# Altium schematic sheet 1power.SchDoc(1power)
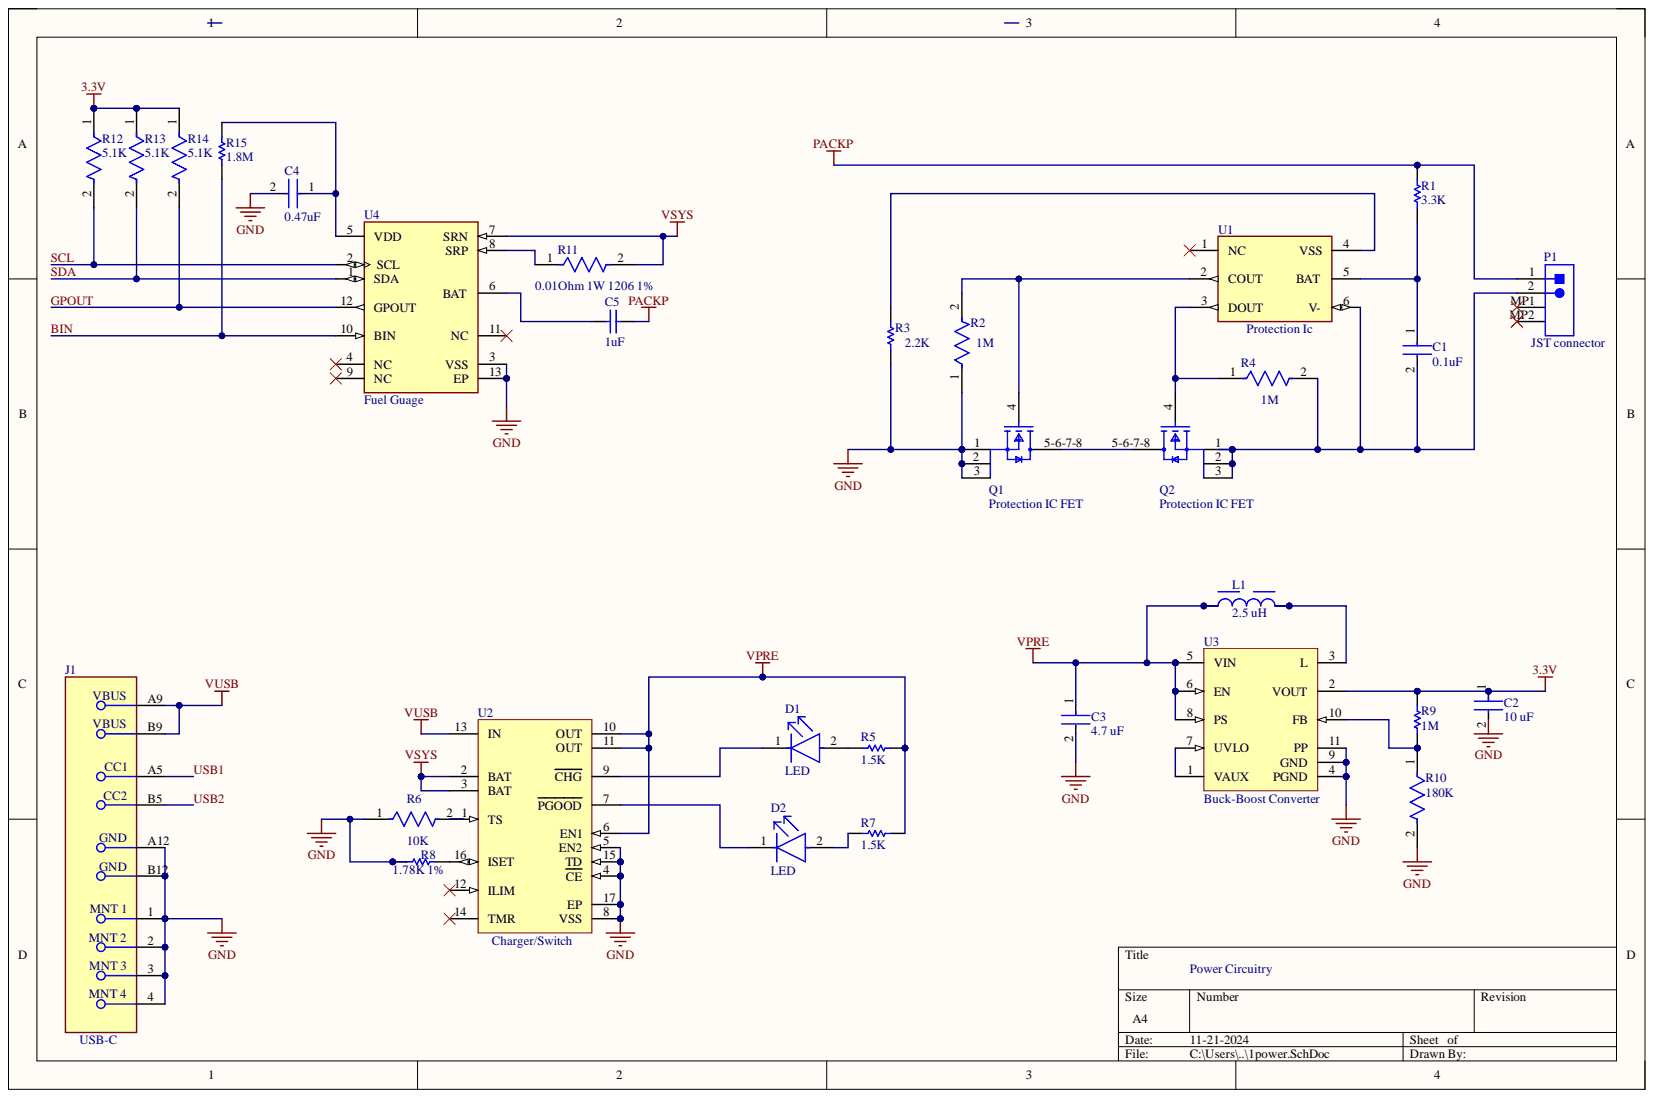
[diagram p0: 1power.SchDoc]

## Parts
C1  0.1uF  [FP-GRM188-0_1-e0_2_0_5-IPC_B]
C2  10 uF  [FP-GRM188-0_1-e0_2_0_55-IPC_A]
C3  4.7 uF  [FP-GRM188-0_15-MFG]
C4  0.47uF  [FP-GRM188-0_1-e0_2_0_5-IPC_B]
C5  1uF  [CAPC1608X87X35LL15T15]
D1  LED  [FP-ASMD-CWG7-NX7D2-MFG]
D2  LED  [FP-ASMD-CWG7-NX7D2-MFG]
J1  USB-C  [FP-USB4125-GF-A-0190-MFG]
L1  2.5 uH  [WE-TPC_3816]
P1  JST connector  [JST- S2B-PH-SM4-TB(LF)(SN)-2_V]
Q1  Protection IC FET  [FP-DQG0008A-MFG]
Q2  Protection IC FET  [FP-DQG0008A-MFG]
R1  3.3K  [RESC1608X55X30LL15T15]
R2  1M  [FP-RC0603-0_55-MFG]
R3  2.2K  [RESC1608X55X25ML10T15]
R4  1M  [FP-RC0603-0_55-MFG]
R5  1.5K  [RESC1609X50X30ML10T20]
R6  10K  [FP-RC0603-0_55-IPC_C]
R7  1.5K  [RESC1609X50X30ML10T20]
R8  1.78K 1%  [RESC1608X55X30LL15T15]
R9  1M  [RESC1608X55X25LL10T15]
R10  180K  [FP-CRCW0603-e3-IPC_A]
R11  0.01Ohm 1W 1206 1%  Resistance Tolerance: 1%  [FP-WSLP1206-FP_1_65x1_93x-MFG]
R12  5.1K  [FP-CRCW0603-e3-IPC_C]
R13  5.1K  [FP-CRCW0603-e3-IPC_C]
R14  5.1K  [FP-CRCW0603-e3-IPC_C]
R15  1.8M  [RESC1608X55X30ML15T15]
U1  Protection Ic  [FP-DSE0006A-IPC_A]
U2  Charger/Switch  [RGT0016C_V]
U3  Buck-Boost Converter  [DRC0010J_V]
U4  Fuel Guage  [FP-DRZ0012A-MFG]

## Nets
3.3V: C2.1 R9.1 R12.1 R13.1 R14.1 U3.2(VOUT)
GND: C2.2 C3.2 C4.2 J1.1 J1.2 J1.3 J1.4 J1.A12 J1.B12 Q1.1 Q1.2 Q1.3 R2.1 R3.2 R6.1 R8.2 R10.2 U2.4(CE) U2.5(EN2) U2.8(VSS) U2.15(TD) U2.17(EP) U3.4(PGND) U3.9(GND) U3.11(PP) U4.3(VSS) U4.13(EP)
PACKP: C5.2 P1.1 R1.1
VPRE: C3.1 L1.1 R5.2 R7.2 U2.6(EN1) U2.10(OUT) U2.11(OUT) U3.5(VIN) U3.6(EN) U3.8(PS)
VSYS: R11.2 U2.2(BAT) U2.3(BAT) U4.7(SRN)
VUSB: J1.A9 J1.B9 U2.13(IN)
BIN: R15.2 U4.10(BIN)
GPOUT: R14.2 U4.12(GPOUT)
SCL: R12.2 U4.2(SCL)
SDA: R13.2 U4.1(SDA)
USB1: J1.A5
USB2: J1.B5
NetC1_1: C1.1 R1.2 U1.5(BAT)
NetC1_2: C1.2 P1.2 Q2.1 Q2.2 Q2.3 R4.2 U1.6(V-)
NetC4_1: C4.1 R15.1 U4.5(VDD)
NetC5_1: C5.1 U4.6(BAT)
NetD1_1: D1.1 U2.9(CHG)
NetD1_2: D1.2 R5.1
NetD2_1: D2.1 U2.7(PGOOD)
NetD2_2: D2.2 R7.1
NetL1_2: L1.2 U3.3(L)
NetQ1_4: Q1.4 R2.2 U1.2(COUT)
NetQ1_5-6-7-8: Q1.5-6-7-8 Q2.5-6-7-8
NetQ2_4: Q2.4 R4.1 U1.3(DOUT)
NetR3_1: R3.1 U1.4(VSS)
NetR6_2: R6.2 U2.1(TS)
NetR8_1: R8.1 U2.16(ISET)
NetR9_2: R9.2 R10.1 U3.10(FB)
NetR11_1: R11.1 U4.8(SRP)
NetU3_1: U3.1(VAUX) U3.7(UVLO)

Not connected: P1 MP1 MP2; U1 1(NC); U2 12(ILIM) 14(TMR); U4 4(NC) 9(NC) 11(NC)

## Library data of the parts
C1 = CMP-1035-02595-8: Chip Multilayer Ceramic Capacitors for General Purpose, 0603, 0.10uF, X7R, 15%, 10%, 100V; Capacitance: 100nF; Case Code (Imperial): 0603; Case Code (Metric): 1608; Case/Package: 0603; Component Kind: Standard; Depth: 0.8mm; Dielectric: X7R; Height: 0.8mm; Lead Free: Lead Free; Length: 1.6mm; Library Name: Altium Content Vault; Material: Ceramic; Max Operating Temperature: 125°C; Min Operating Temperature: -55°C; Mount: Surface Mount; Package Quantity: 1; Packaging: Tape and Reel; Radiation Hardening: No; REACH SVHC: No SVHC; Termination: SMD/SMT; Thickness: 0.035inch; Tolerance: 10%; Voltage: 100V; Voltage Rating: 100V; Voltage Rating (DC): 100V; Weight: 0.000222oz; Width: 0.8mm
C2 = CMP-2006-02792-2: Chip Multilayer Ceramic Capacitors for General Purpose, 0603, 10uF, X5R, 15%, 20%, 6.3V; Capacitance: 10uF; Case Code (Imperial): 0603; Case Code (Metric): 1608; Case/Package: 0603; Component Kind: Standard; Depth: 0.8mm; Dielectric: X5R; Height: 0.8mm; Lead Free: Lead Free; Length: 1.6mm; Library Name: Altium Content Vault; Material: Ceramic; Max Operating Temperature: 85°C; Min Operating Temperature: -55°C; Mount: Surface Mount; Package Quantity: 4000; Packaging: Tape and Reel; Radiation Hardening: No; REACH SVHC: No SVHC; Termination: SMD/SMT; Thickness: 0.035inch; Tolerance: 20%; Voltage: 6.3V; Voltage Rating: 6.3V; Voltage Rating (DC): 6.3V; Width: 0.031inch
C3 = CMP-06035-062935-2: CAP CER 4.7UF 16V X5R 0603; NexarPartId: 20311162; Capacitance: 4.7µF; Material: Ceramic; Tolerance: 10%; Case Code (Imperial): 0603; Case Code (Metric): 1608; Case/Package: 0603; Component Kind: Standard; Depth: 800µm; Dielectric: X5R; Height: 800µm; Lead Free: Lead Free; Length: 1.6mm; Library Name: Altium Content Vault; Lifecycle Status: Production; Max Operating Temperature: 85°C; Min Operating Temperature: -55°C; Mount: Surface Mount; Packaging: Tape & Reel (TR); REACH SVHC: No SVHC; RoHS: Compliant; Schedule B: 8532240020; Termination: SMD/SMT; Thickness: 939.8µm; Voltage Rating: 16V; Voltage Rating (DC): 16V; Weight: 6.293594mg; Width: 800µm
C4 = CMP-2006-02860-2: Chip Multilayer Ceramic Capacitors for General Purpose, 0603, 0.47uF, X7R, 15%, 10%, 16V; Capacitance: 470nF; Case Code (Imperial): 0603; Case Code (Metric): 1608; Case/Package: 0603; Component Kind: Standard; Depth: 0.8mm; Dielectric: X7R; Height: 0.8mm; Lead Free: Lead Free; Length: 1.6mm; Library Name: Altium Content Vault; Material: Ceramic; Max Operating Temperature: 125°C; Min Operating Temperature: -55°C; Mount: Surface Mount; Number of Pins: 2; Package Quantity: 1; Packaging: Tape and Reel; REACH SVHC: No SVHC; Termination: SMD/SMT; Thickness: 0.035inch; Tolerance: 10%; Voltage: 16V; Voltage Rating: 16V; Voltage Rating (DC): 16V; Width: 0.8mm
C5 = CMP-2006-03713-1: Manufacturer: Kemet; Part Number: C0603C105K3RACTU; Component Kind: Standard; Library Name: Altium Content Vault
D1 D2 = CMP-02129-107448438-1: ASMD-CWG7-NX7D2 ASMD-CWG7 Rectangle with Flat Top White Cool Surface Mount LED Indication Discrete 2.8V 20mA 2800mcd 120°; Luminous Intensity: 2.8cd; Max Junction Temperature (Tj): 110°C; Power Dissipation: 102mW; Case/Package: 1206; Color: White; Component Kind: Standard; Forward Current: 20mA; Forward Voltage: 2.8V; Height: 900µm; Library Name: Altium Content Vault; Max Operating Temperature: 85°C; Min Operating Temperature: -40°C; NexarPartId: 107448438; Viewing Angle: 120°
J1 = CMP-245163-000004-1: CONN RCPT TYPE C 6P SMD RA; Component Kind: Standard; Library Name: Schlib1.SchLib; Supplier 1: Digikey; Supplier Part Number 1: 2073-USB4125-GF-A-0190CT-ND
L1 = CMP-0227-00365-3: NexarPartId: 22803208; Core Material: Ferrite; Current Rating: 1.45A; DC Resistance (DCR): 50mΩ; Inductance: 2.5µH; Inductance Tolerance: 30%; Saturation Current: 1.25A; Self Resonant Frequency: 120MHz; Shielding: Shielded; Test Frequency: 100kHz; Ambient Temperature Range High: 95°C; Ambient Temperature Range Low: -40°C; Case/Package: Nonstandard; Component Kind: Standard; Composition: Wirewound; DC Current: 1.45A; Depth: 4.1mm; Height: 1.65mm; Height - Seated (Max): 1.8034mm; Lead Pitch: 1.1mm; Length: 3.8mm; Library Name: Altium Content Vault; Max DC Current: 1.45A; Max Operating Temperature: 125°C; Military Standard: Not; Min Operating Temperature: -40°C; Mount: Surface Mount; Number of Pins: 2; Packaging: Cut Tape (CT); REACH SVHC: Unknown; RMS Current (Irms): 1.45A; RoHS: Compliant; Termination: SMD/SMT; Tolerance: 30%; Weight: 102.993818mg; Width: 3.8mm
P1 = CMP-1755-00001-1: Male Header, Pitch 2 mm, 1 x 2 Position, Height 5.5 mm, -25 to 85 degC, RoHS, Tape and Reel; Current Rating: 3 A; Colour: Black; Component Kind: Standard; ComponentLink1Description: Manufacturer URL; ComponentLink1URL: http://www.jst.com/home2.html; ComponentLink2Description: Datasheet; ComponentLink2URL: http://www.jst-mfg.com/product/pdf/eng/ePH.pdf; Contact Finish: Lead; Contact Material: Bronze; Contact Plating: Tin; DatasheetVersion: Dec-12; Height: 8.13 mm; Lead Pitch: 0.1 inch; Length: 101.19 mm; Library Name: Altium Content Vault; Max Operating Temperature: 105degC; Min Operating Temperature: -65degC; Mount: Through Hole; Package Quantity: 100; PackageDescription: Male Header, Pitch 2 mm, 1 x 2 Position, Height 5.5 mm; PackageReference: S2B-PH-SM4-TB(LF)(SN)-2; Packaging: Bulk; Pins: 40; Radiation Hardening: No; RoHSCompliant: True; Width: 2.34 mm
Q1 Q2 = CMP-04962-000044-1: Protection IC FET, MOSFET N-CH 25V 60A 8-SON, CSD16406Q3; Element Configuration: Single; Fall Time: 4.8ns; Gate to Source Voltage (Vgs): 16V; Input Capacitance: 1.1nF; Max Power Dissipation: 2.7W; Nominal Vgs: 1.7V; Number of Elements: 1; Polarity: N-CHANNEL; Rds On Max: 5.3mR; Rise Time: 12.9ns; Turn-Off Delay Time: 8.5ns; Turn-On Delay Time: 7.3ns; Case/Package: SON; Component Kind: Standard; Contact Plating: Tin; Continuous Drain Current (ID): 60A; Drain to Source Breakdown Voltage: 25V; Drain to Source Voltage (Vdss): 25V; Dual Supply Voltage: 25V; Lead Free: Contains Lead; Library Name: Schlib1.SchLib; Max Operating Temperature: 150°C; Min Operating Temperature: -55°C; Mount: Surface Mount; Number of Pins: 8; Package Quantity: 1; Packaging: Tape and Reel; Power Dissipation: 2.7W; Radiation Hardening: No; REACH SVHC: No SVHC; RoHS Compliant: Yes; Series: NexFET™; Supplier 1: Mouser; Supplier Part Number 1: 595-CSD16406Q3; Termination: SMD/SMT; Threshold Voltage: 1.7V
R1 = CMP-2000-00435-2: Chip Resistor, 330 Ohm, +/- 1%, 0.1 W, -55 to 155 degC, 0603 (1608 Metric), RoHS, Tape and Reel; Current Rating: 500 mA; Gender: Male; Mating Cycles: 10000; Voltage Rating: 10 V; Component Kind: Standard; ComponentLink1Description: Manufacturer URL; ComponentLink1URL: http://www.panasonic.com/; ComponentLink2Description: Datasheet; ComponentLink2URL: http://industrial.panasonic.com/cdbs/www-data/pdf/RDA0000/AOA0000C86.pdf; Contact Finish: Gold; Contact Material: Copper; Contact Plating: Gold; DatasheetVersion: Apr-15; Height: 1.45 mm; Lead Pitch: 1.1 mm; Length: 13.7 mm; Library Name: Altium Content Vault; Max Operating Temperature: 85 degC; Min Operating Temperature: -25 degC; Mount: Surface Mount; Package Quantity: 1500; PackageDescription: 2-Pin Surface Mount Device, Body 1.6 x 0.85 mm; PackageReference: 0603; Packaging: Tape and Reel; Pins: 8; REACH SVHC: No SVHC; RoHSCompliant: true; Width: 1.45 mm
R2 R4 = CMP-2000-06953-2: 1MOhm, RC0603JR-071ML, RES SMD 1M OHM 5% 1/10W 0603; Max Power Dissipation: 100mW; Resistance: 1MR; Temperature Coefficient: 100ppm/°C; Voltage Rating: 50V; Case Code (Imperial): 0603; Case Code (Metric): 1608; Case/Package: 0603; Component Kind: Standard; Construction: Rectangular; Contact Plating: Tin; Depth: 0.8mm; Features: Moisture Resistant; Height: 0.45mm; Lead Free: Lead Free; Length: 1.6mm; Library Name: Schlib1.SchLib; Max Operating Temperature: 155°C; Military Standard: Not; Min Operating Temperature: -55°C; Number of Pins: 2; Number of Terminations: 2; Package Quantity: 5000; Packaging: Tape and Reel; Power Rating: 100mW; Radiation Hardening: No; REACH SVHC: No SVHC; Resistance Tolerance: 5%; Resistor Type: Thick Film; RoHS Compliant: Yes; Series: RC; Size Code: 0603; Termination: SMD/SMT; Tolerance: 5%; Voltage Rating (DC): 50V; Width: 0.8mm
R3 = CMP-1012-00659-3: RC0603FR-0722KL, Chip Resistor, 22 KOhm, +/- 1%, 0.1 W, -55 to 155 degC, 0603 (1608 Metric), RoHS, Tape and Reel; Current Rating: 290 mA; Resistance: 2.9 Ohm; Shielding: Unshielded; Tolerance: 10%; Case/Package: Radial; Component Kind: Standard; ComponentLink1Description: Manufacturer URL; ComponentLink1URL: http://www.yageo.com/; ComponentLink2Description: Datasheet; ComponentLink2URL: http://www.yageo.com/documents/recent/PYu-RC_Group_51_RoHS_L_04.pdf; DatasheetVersion: Rev. 4, 02/2016; Diameter: 8.5 mm; Height: 11 mm; Lead Pitch: 5 mm; Library Name: Schlib1.SchLib; Max Operating Temperature: 105degC; Min Operating Temperature: -55degC; Mount: Through Hole; Package Quantity: 100; PackageDescription: 2-Pin Surface Mount Device, Body 1.6 x 0.8 mm; PackageReference: 0603; Packaging: Bulk; Pins: 2; Radiation Hardening: No; REACH SVHC: No SVHC; RoHSCompliant: True; Supplier 1: Digikey; Supplier Part Number 1: 311-22.0KHRCT-ND
R5 R7 = CMP-2000-02997-1: Manufacturer: Vishay Dale; Part Number: CRCW06031K50JNEA; Component Kind: Standard; Library Name: Altium Content Vault
R6 = CMP-2100-03664-2: RES 10K OHM 1% 1/10W 0603; Max Power Dissipation: 100mW; Resistance: 10kR; Temperature Coefficient: 100ppm/°C; Voltage Rating: 75V; Voltage Rating (DC): 50V; Case Code (Imperial): 0603; Case Code (Metric): 1608; Case/Package: 0603; Component Kind: Standard; Construction: Rectangular; Depth: 0.8mm; Features: Moisture Resistant; Height: 0.45mm; Lead Free: Lead Free; Lead Pitch: 0.3mm; Length: 1.6mm; Library Name: Altium Content Vault; Max Operating Temperature: 155°C; Military Standard: Not; Min Operating Temperature: -55°C; Number of Pins: 2; Number of Terminations: 2; Packaging: Tape and Reel; Power Rating: 100mW; Resistance Tolerance: 1%; Resistor Type: Thick Film; RoHS Compliant: Yes; Series: RC; Size Code: 0603; Termination: SMD/SMT; Tolerance: 1%; Weight: 7.1E-05oz; Width: 0.8mm
R8 = CMP-2000-00290-1: Manufacturer: Panasonic; Part Number: ERJ-3EKF1781V; Component Kind: Standard; Library Name: Altium Content Vault
R9 = CMP-1659-00056-1: Chip Resistor, 1 MOhm, +/- 1%, 100 mW, -55 to 155 degC, 0603 (1608 Metric), RoHS, Tape and Reel; Capacitance: 1 mF; Case\Package: Radial; Tolerance: 20%; Voltage Rating: 25 V; Component Kind: Standard; ComponentLink1Description: Manufacturer URL; ComponentLink1URL: http://www.yageo.com/; ComponentLink2Description: Datasheet; ComponentLink2URL: http://www.yageo.com/documents/recent/PYu-RC_Group_51_RoHS_L_04.pdf; DatasheetVersion: Rev. 4, 02/2016; Diameter: 10 mm; Height: 20 mm; Lead Pitch: 5 mm; Length: 20 mm; Library Name: Altium Content Vault; Max Operating Temperature: 85 degC; Min Operating Temperature: -40 degC; Mount: Through Hole; Package Quantity: 200; PackageDescription: 2-Pin Surface Mount Device, Body 1.6 x 0.8 mm; PackageReference: 0603; Packaging: Bulk; Radiation Hardening: No; REACH SVHC: No SVHC; RoHSCompliant: true; Voltage Rating (DC): 25 V; Width: 0 inch
R10 = CMP-2000-02950-2: RES Thick Film, 180kΩ, 1%, 0.1W, 100ppm/°C, 0603; Max Power Dissipation: 100mW; Resistance: 180kR; Voltage Rating: 75V; Case Code (Imperial): 0603; Case Code (Metric): 1608; Case/Package: 0603; Component Kind: Standard; Composition: Thick Film; Contact Plating: Tin; Depth: 0.85mm; Features: Automotive AEC-Q200; Height: 0.45mm; Lead Free: Lead Free; Length: 1.55mm; Library Name: Altium Content Vault; Max Operating Temperature: 155°C; Military Standard: Not; Min Operating Temperature: -55°C; Number of Terminations: 2; Package Quantity: 10; Packaging: Tape and Reel; Power Rating: 100mW; Radiation Hardening: No; Temperature Coefficient: 100ppm/°C; Termination: SMD/SMT; Tolerance: 1%; Voltage Rating (DC): 75V; Weight: 7.1E-05oz; Width: 0.85mm
R11 = CMP-2003-04373-2: RES 0.01 OHM 1% 1W 1206; Resistance: 10mR; Temperature Coefficient: 75ppm/°C; Case Code (Imperial): 1206; Case Code (Metric): 3216; Case/Package: 1206; Component Kind: Standard; Construction: Rectangular; Contact Plating: Tin; Depth: 1.6mm; Features: Moisture Resistant; Height: 0.035inch; Lead Free: Lead Free; Length: 3.2mm; Library Name: Altium Content Vault; Max Operating Temperature: 170°C; Max Power Dissipation: 1W; Military Standard: Not; Min Operating Temperature: -65°C; Mount: Surface Mount; Number of Pins: 2; Number of Terminations: 2; Package Quantity: 1; Packaging: Tape and Reel; Power Rating: 1W; Radiation Hardening: No; Resistor Type: Metal Strip; RoHS Compliant: Yes; Series: WSLP; Size Code: 1206; Termination: SMD/SMT; Tolerance: 1%; Weight: 0.000571oz; Width: 0.063inch
R12-R14 = CMP-1012-00590-3: RES Thick Film, 5.1kΩ, 1%, 0.1W, 100ppm/°C, 0603; Power Rating: 100mW; Resistance: 5.1kR; Voltage Rating: 75V; Case Code (Imperial): 0603; Case Code (Metric): 1608; Case/Package: 0603; Component Kind: Standard; Composition: Thick Film; Contact Plating: Tin; Depth: 0.85mm; Height: 0.45mm; Lead Free: Lead Free; Length: 1.55mm; Library Name: Altium Content Vault; Max Operating Temperature: 155°C; Military Standard: Not; Min Operating Temperature: -55°C; Mount: Surface Mount; Package Quantity: 5000; Packaging: Tape and Reel; Radiation Hardening: No; Temperature Coefficient: 100ppm/°C; Termination: SMD/SMT; Tolerance: 1%; Voltage Rating (DC): 75V; Weight: 7.1E-05oz; Width: 0.85mm
R15 = CMP-2000-00297-1: Manufacturer: Panasonic; Part Number: ERJ-3EKF1804V; Component Kind: Standard; Library Name: Altium Content Vault
U1 = BQ29701DSER: Power Supply Support Circuit, Fixed, 1 Channel, PDSO6; Manufacturer: Texas Instruments; Adjustable Threshold: No; Channels: 1; Max Supply Voltage: 8V; Min Supply Voltage: 1.5V; Nominal Supply Voltage: 3.6V; Package Body Material: Plastic; Case/Package: VSON; Category: Battery Management; Component Kind: Standard; ComponentLink1Description: https://www.ti.com:443/lit/ds/symlink/bq2970.pdf?src-supplier=IHS+Markit; ComponentLink1URL: https://www.ti.com:443/lit/ds/symlink/bq2970.pdf?src-supplier=IHS+Markit; ComponentLink2Description: http://www.ti.com/lit/gpn/bq2970?src-supplier=Mouser; ComponentLink2URL: http://www.ti.com/lit/gpn/bq2970?src-supplier=Mouser; ComponentLink3Description: http://www.ti.com/lit/ds/symlink/bq2971.pdf?src-supplier=Digikey; ComponentLink3URL: http://www.ti.com/lit/ds/symlink/bq2971.pdf?src-supplier=Digikey; ComponentLink4Description: http://www.ti.com/general/docs/suppproductinfo.tsp?distId=10&amp;gotoUrl=http%3A%2F%2Fwww.ti.com%2Flit%2Fgpn%2Fbq2971&src-supplier=Digikey; ComponentLink4URL: http://www.ti.com/general/docs/suppproductinfo.tsp?distId=10&amp;gotoUrl=http%3A%2F%2Fwww.ti.com%2Flit%2Fgpn%2Fbq2971&src-supplier=Digikey; ComponentLink5Description: http://www.ti.com/general/docs/lit/getliterature.tsp?genericPartNumber=BQ2970&&fileType=pdf&src-supplier=Avnet; ComponentLink5URL: http://www.ti.com/general/docs/lit/getliterature.tsp?genericPartNumber=BQ2970&&fileType=pdf&src-supplier=Avnet; Height - Seated (Max): 0.8mm; JESD-30 Code: S-PDSO-N6; JESD-609 Code: e4; Length: 1.5mm; Library Name: Schlib1.SchLib; Manufacturer Part Number: BQ29701DSER; Max Operating Temperature: 85°C; Min Operating Temperature: -40°C; Moisture Sensitivity Level: 1; Number of Channels: 1; Package Shape: Square; Package Style: SMALL OUTLINE, VERY THIN PROFILEMeter; Peak Reflow Temperature (Cel): 260°C; Pins: 6; Pricing: 1=0.45, 10=0.316, 25=0.2824, 100=0.2448, 250=0.22688, 500=0.2161, 1000=0.20722, 3000=0.19625, 6000=0.19088, 9000=0.18819, 15000=0.18521, 21000=0.18347, 30000=0.18179 (USD); Reach SVHC Compliant: Yes; RoHS: Yes; RoHS Compliant: Yes; Stock: 825; Supplier: Digikey; Supplier 1: Digikey; Supplier Part Number: 296-39944-1-ND; Supplier Part Number 1: 296-39944-1-ND; Surface Mount: Yes; Temperature Grade: INDUSTRIAL; Terminal Finish: Nickel; Terminal Form: No Lead; Terminal Pitch: 0.5mm; Terminal Position: DUAL; Throw Configuration: POWER SUPPLY SUPPORT CIRCUIT; Width: 1.5mm
U2 = CMP-0321-00226-2: USB-Friendly Li-Ion Battery Charger and Power-Path Management IC, Vout 200 mV above Vbat, 4.3 V, -40 to 85 degC, 16-pin QFN (RGT), Green (RoHS & no Sb/Br); Manufacturer: Texas Instruments; PartNumber: BQ24072RGTT; Lead Acid: N; Li-Ion: Y; SubFamily: Battery Charge Management; Vin(Min)(V): 4.3; Code_JEDEC: MO-220; Component Kind: Standard; ComponentLink1Description: Datasheet; ComponentLink1URL: http://www.ti.com/general/docs/lit/getliterature.tsp?genericPartNumber=BQ24072&fileType=pdf; DatasheetVersion: SLUS810G; Eco-Plan: Green (RoHS & no Sb/Br); Li-Polymer: Y; Library Name: Altium Content Vault; Mounting Technology: Surface Mount; NiCd: N; NiMH: N; Over Voltage Protection: N; PackageDescription: 16-Pin Plastic Quad Flat No-Lead, 3 x 3 mm Body, 0.5 mm Pitch, 1.7 x 1.7 mm Exposed Pad; PackageReference: RGT0016C; PackageVersion: revH, Oct-2011
U3 = CMP-0347-00023-2: Boost Voltage Converter with 0.3 to 5.5 V Input and 1.8 to 5.5 V Output, -40 to 85 degC, 10-Pin SON (DRC), Green (RoHS & no Sb/Br); Manufacturer: Texas Instruments; PartNumber: TPS61200DRCT; Regulated Outputs(#): 1; SubFamily: Step-Up Regulator; Switching Frequency(Max)(kHz): 1250; Synchronous Rectification: TRUE; Vin(Max)(V): 5.5; Vin(Min)(V): 0.3; Vout(Min)(V): 1.8; Component Kind: Standard; ComponentLink1Description: Datasheet; ComponentLink1URL: http://www.ti.com/general/docs/lit/getliterature.tsp?genericPartNumber=TPS61200&fileType=pdf; ComponentLink2Description: Package Specification; ComponentLink2URL: http://www.ti.com/litv/pdf/mpds117k; DatasheetVersion: SLVS577B; Eco-Plan: Green (RoHS & no Sb/Br); Library Name: Altium Content Vault; Mounting Technology: Surface Mount; PackageDescription: 10-Pin Plastic Small Outline No-Lead, 3 x 3 x 1 mm Body, 0.5 mm Pitch, Exposed Pad; PackageReference: DRC0010J; PackageVersion: revL, Sep-2011; Topology: Boost; Vout(Max)(V): 5.5
U4 = CMP-04911-000005-1: Battery Battery Monitor IC Lithium-Ion 12-SON (2.5x4); Manufacturer: Texas Instruments; Manufacturer Part Number: BQ27441DRZT-G1A; Max Supply Voltage: 4.5V; Min Supply Voltage: 2.45V; Nominal Supply Current: 93uA; Number Of Cells: 1; Output Voltage: 1.8V; Standoff Height: 0mm; Ambient Temperature Range High: +85°C; Ambient Temperature Range Low: -40°C; Automotive: No; Category: IC; Component Kind: Standard; ComponentLink2Description: Datasheet; ComponentLink2URL: http://www.ti.com/lit/ds/symlink/bq27441-g1.pdf; Device Class L1: Integrated Circuits (ICs); Device Class L2: Power Management ICs; Device Class L3: Battery Management; Digikey Description: IC BATT FUEL GAUGE LICOO2 12SON; Height: 1mm; Lead Free: Yes; Library Name: Altium Content Vault; Mouser Description: Battery Management System-Side Impdance Trck Bttry Fuel Gge; Number Of Outputs: 1; Octopart: https://octopart.com/bq27441drzt-g1a-texas+instruments-30555831; Package: DRZ0012A; RoHS: TRUE; Temperature: -40°C to +85°C; Temperature Range High: +85°C; Temperature Range Low: -40°C
